# Altium schematic sheet 09_CAN.SchDoc(09_CAN)
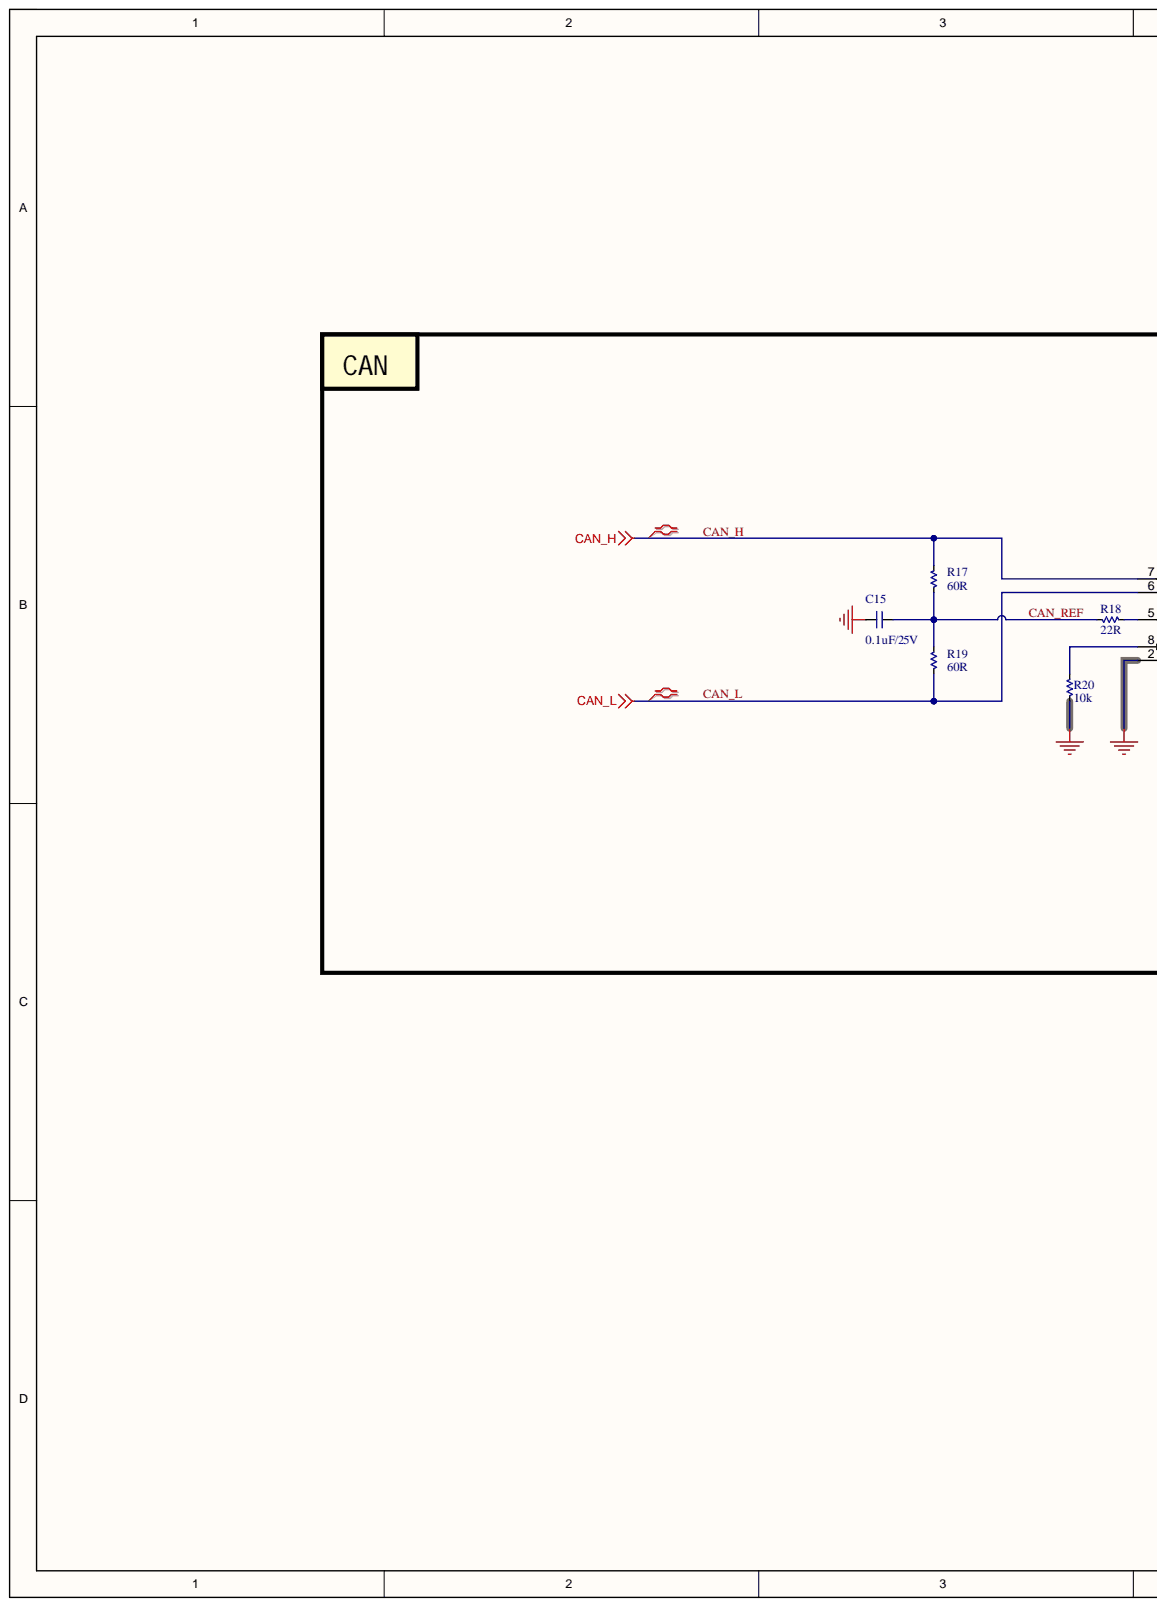
[diagram p0: 09_CAN.SchDoc, left]
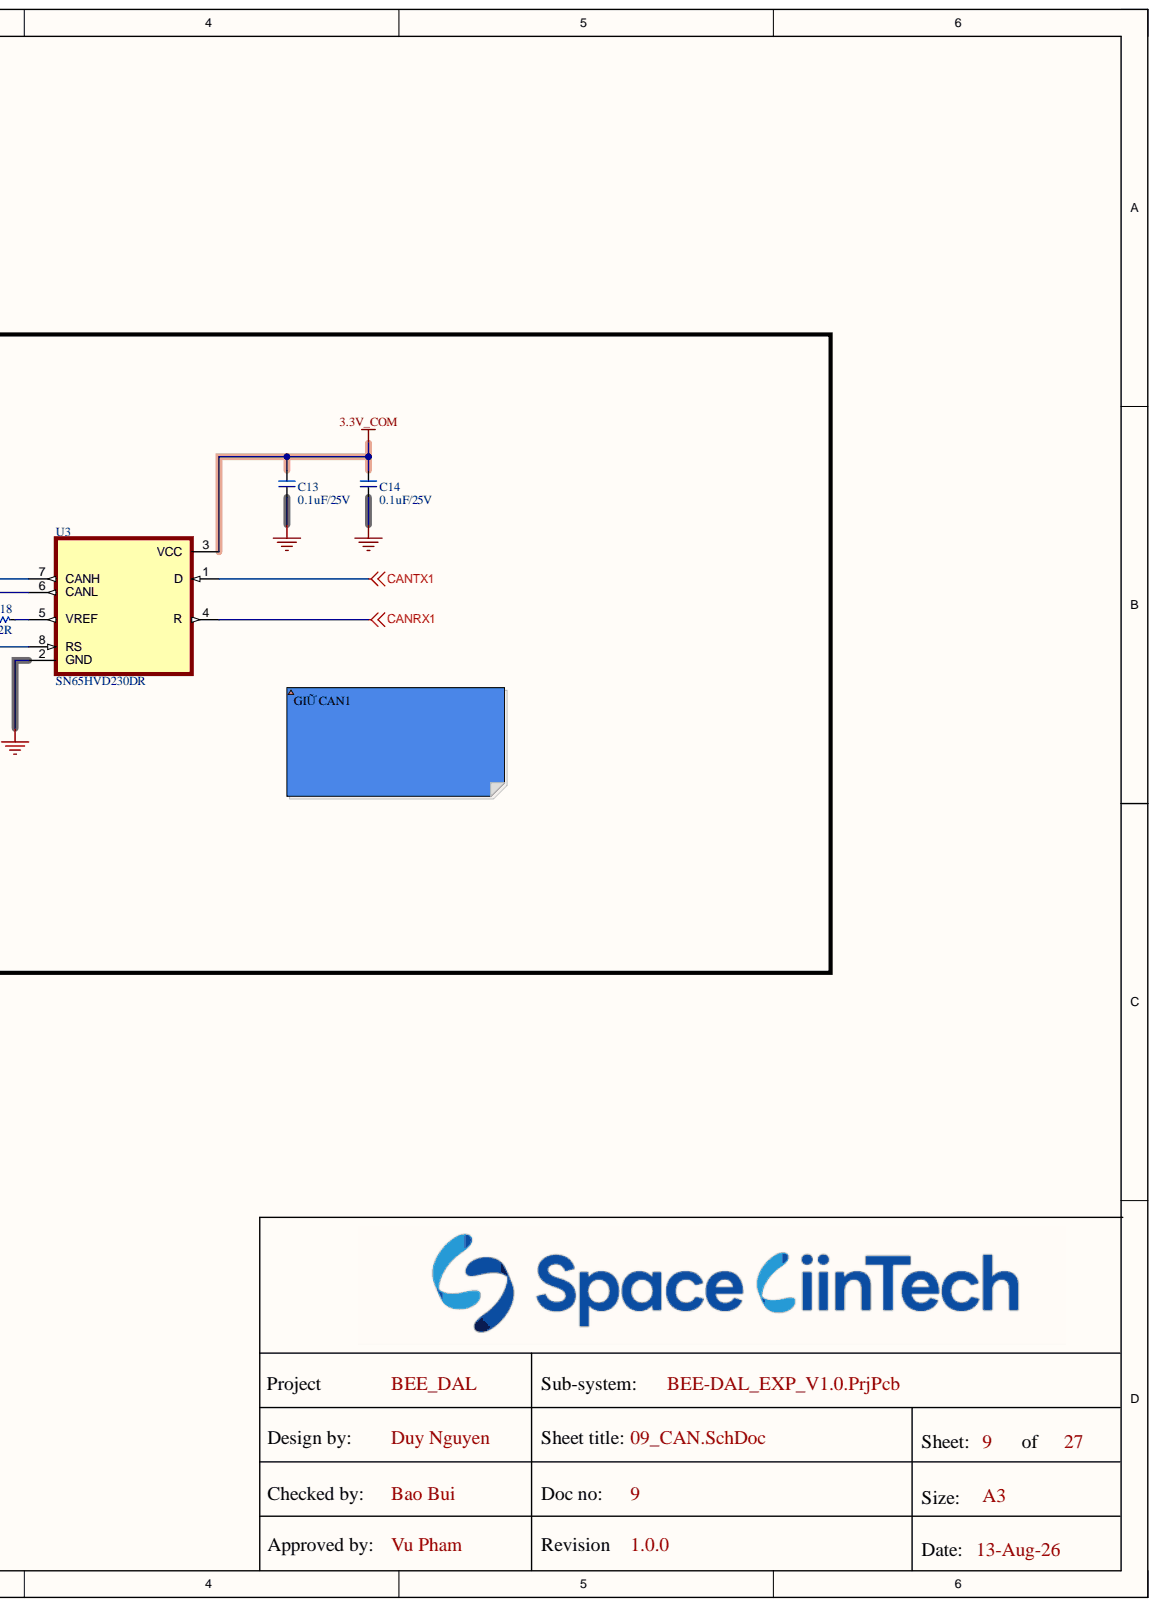
[diagram p1: 09_CAN.SchDoc, right]

## Parts
C13  0.1uF/25V  [CAP_C_1005X60]
C14  0.1uF/25V  [CAP_C_1005X60]
C15  0.1uF/25V  [CAP_C_1005X60]
R17  60R  [RES_C_1005X40]
R18  22R  [RES_C_1005X40]
R19  60R  [RES_C_1005X40]
R20  10k  [RES_C_1005X40]
U3  SN65HVD230DR  [SOIC127P600X175-8N]

## Nets
3.3V_COM: C13.1 C14.1 U3.3(VCC)
CANRX1: U3.4(R)
CANTX1: U3.1(D)
GND: C13.2 C14.2 C15.2 R20.1 U3.2(GND)
CAN_H: R17.2 U3.7(CANH)
CAN_L: R19.1 U3.6(CANL)
CAN_REF: C15.1 R17.1 R18.1 R19.2
NetR18_2: R18.2 U3.5(VREF)
NetR20_2: R20.2 U3.8(RS)

## Library data of the parts
C13-C15 = _CAP_C_2: CAP; Component Kind: Standard; Library Name: LABLIB.SchLib
R17-R20 = _RES_B_2: RES; Component Kind: Standard; Library Name: LABLIB.SchLib
U3 = SN65HVD230DR: 3.3 V CAN Transceiver with Standby Mode; MF: Texas Instruments; Check_prices: https://www.snapeda.com/parts/SN65HVD230DR/Texas+Instruments/view-part/?ref=eda; Component Kind: Standard; MP: SN65HVD230DR; Package: SOIC-8 Texas Instruments; SnapEDA_Link: https://www.snapeda.com/parts/SN65HVD230DR/Texas+Instruments/view-part/?ref=snap; Library Name: BEE-1012 PAY-EXP_V110.IntLib
